# Altium schematic sheet [06] - Backlight LEDs.SchDoc("[06] - Backlight LEDs")
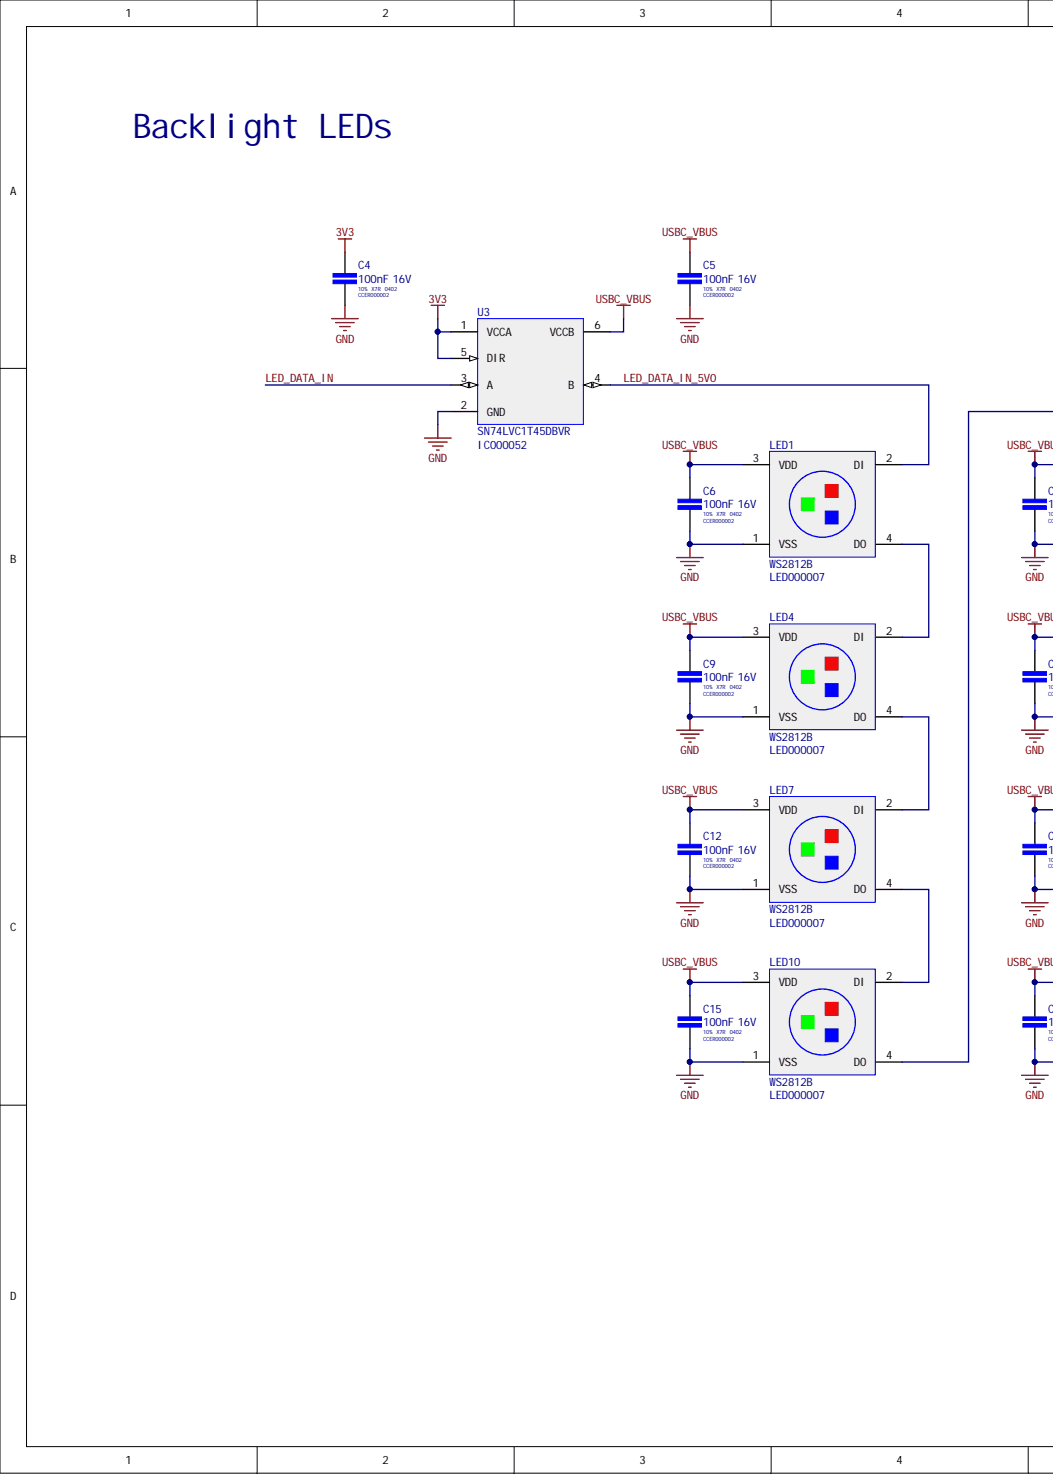
[diagram p0: [06] - Backlight LEDs.SchDoc, left]
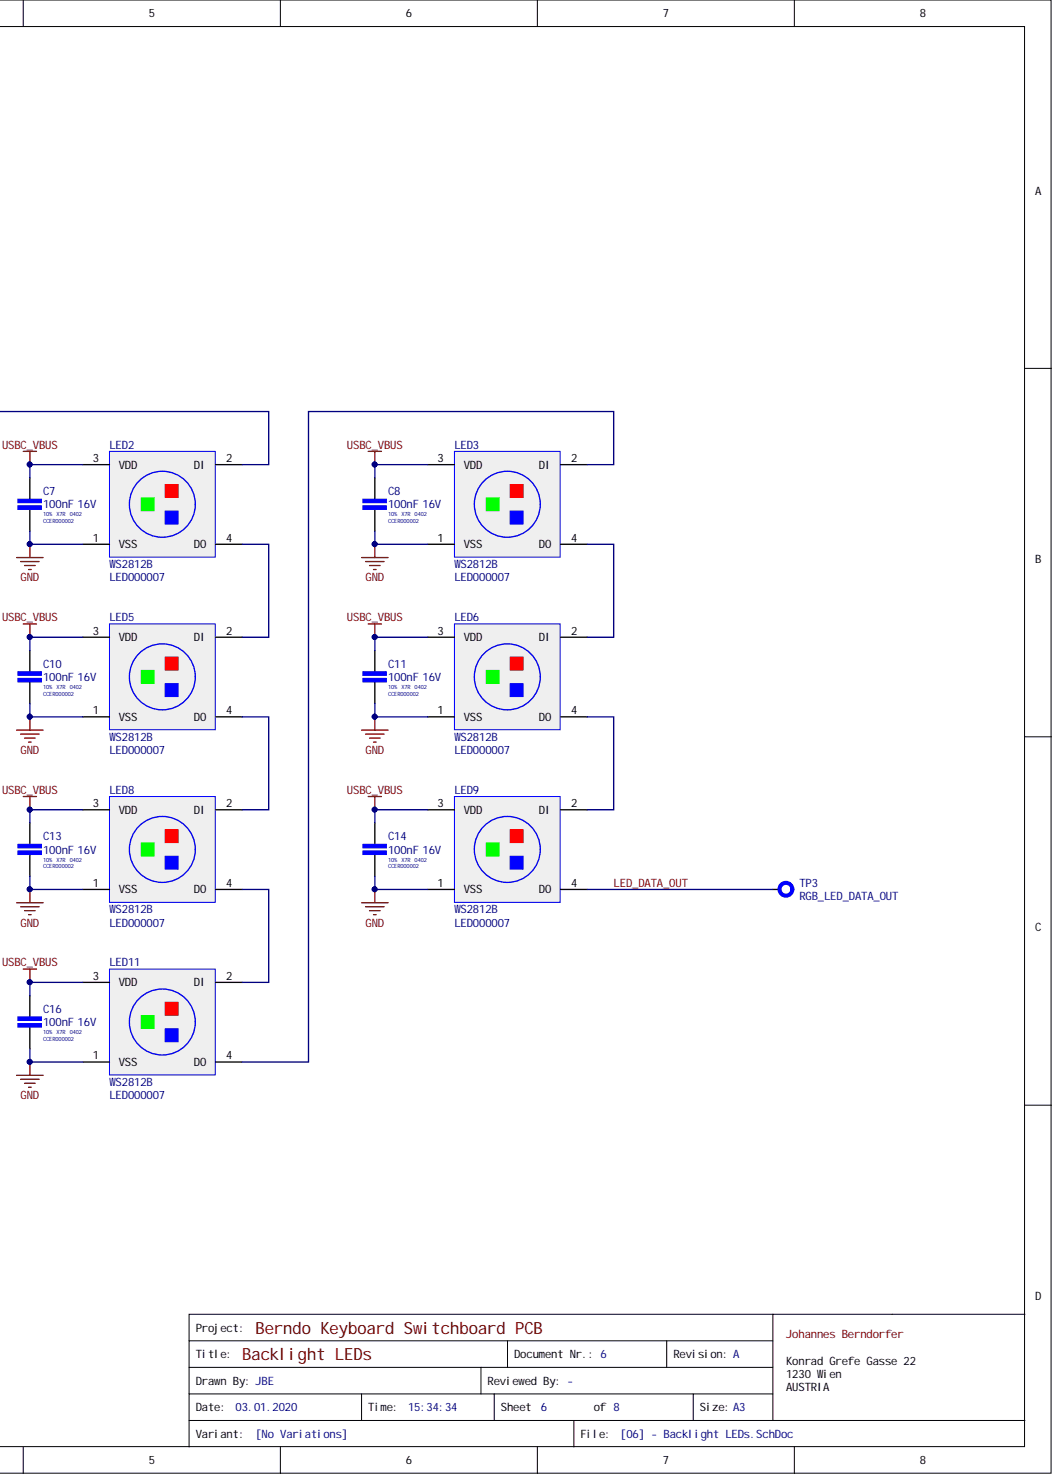
[diagram p1: [06] - Backlight LEDs.SchDoc, right]

## Parts
C4  100nF 16V  Tolerance: 10%  Internal Part Number: CCER000002  Dielectric: X7R  Case Code (Imperial): 0402  Capacitance: 100nF  [CAP-SMD-0402-0.55MM-IPC-MED]
C5  100nF 16V  Tolerance: 10%  Internal Part Number: CCER000002  Dielectric: X7R  Case Code (Imperial): 0402  Capacitance: 100nF  [CAP-SMD-0402-0.55MM-IPC-MED]
C6  100nF 16V  Tolerance: 10%  Internal Part Number: CCER000002  Dielectric: X7R  Capacitance: 100nF  [CAP-SMD-0402-0.55MM-IPC-MED]
C7  100nF 16V  Tolerance: 10%  Internal Part Number: CCER000002  Dielectric: X7R  Capacitance: 100nF  [CAP-SMD-0402-0.55MM-IPC-MED]
C8  100nF 16V  Tolerance: 10%  Internal Part Number: CCER000002  Dielectric: X7R  Capacitance: 100nF  [CAP-SMD-0402-0.55MM-IPC-MED]
C9  100nF 16V  Tolerance: 10%  Internal Part Number: CCER000002  Dielectric: X7R  Capacitance: 100nF  [CAP-SMD-0402-0.55MM-IPC-MED]
C10  100nF 16V  Tolerance: 10%  Internal Part Number: CCER000002  Dielectric: X7R  Capacitance: 100nF  [CAP-SMD-0402-0.55MM-IPC-MED]
C11  100nF 16V  Tolerance: 10%  Internal Part Number: CCER000002  Dielectric: X7R  Capacitance: 100nF  [CAP-SMD-0402-0.55MM-IPC-MED]
C12  100nF 16V  Tolerance: 10%  Internal Part Number: CCER000002  Dielectric: X7R  Capacitance: 100nF  [CAP-SMD-0402-0.55MM-IPC-MED]
C13  100nF 16V  Tolerance: 10%  Internal Part Number: CCER000002  Dielectric: X7R  Capacitance: 100nF  [CAP-SMD-0402-0.55MM-IPC-MED]
C14  100nF 16V  Tolerance: 10%  Internal Part Number: CCER000002  Dielectric: X7R  Capacitance: 100nF  [CAP-SMD-0402-0.55MM-IPC-MED]
C15  100nF 16V  Tolerance: 10%  Internal Part Number: CCER000002  Dielectric: X7R  Capacitance: 100nF  [CAP-SMD-0402-0.55MM-IPC-MED]
C16  100nF 16V  Tolerance: 10%  Internal Part Number: CCER000002  Dielectric: X7R  Capacitance: 100nF  [CAP-SMD-0402-0.55MM-IPC-MED]
LED1  WS2812B  Internal Part Number: LED000007  [LED-SMD-4-SMD-5050-WS2812B]
LED2  WS2812B  Internal Part Number: LED000007  [LED-SMD-4-SMD-5050-WS2812B]
LED3  WS2812B  Internal Part Number: LED000007  [LED-SMD-4-SMD-5050-WS2812B]
LED4  WS2812B  Internal Part Number: LED000007  [LED-SMD-4-SMD-5050-WS2812B]
LED5  WS2812B  Internal Part Number: LED000007  [LED-SMD-4-SMD-5050-WS2812B]
LED6  WS2812B  Internal Part Number: LED000007  [LED-SMD-4-SMD-5050-WS2812B]
LED7  WS2812B  Internal Part Number: LED000007  [LED-SMD-4-SMD-5050-WS2812B]
LED8  WS2812B  Internal Part Number: LED000007  [LED-SMD-4-SMD-5050-WS2812B]
LED9  WS2812B  Internal Part Number: LED000007  [LED-SMD-4-SMD-5050-WS2812B]
LED10  WS2812B  Internal Part Number: LED000007  [LED-SMD-4-SMD-5050-WS2812B]
LED11  WS2812B  Internal Part Number: LED000007  [LED-SMD-4-SMD-5050-WS2812B]
TP3  RGB_LED_DATA_OUT  [TP-SMD-CIRCULAR-1MM]
U3  SN74LVC1T45DBVR  Internal Part Number: IC000052  [SOT-23-6-H1.45MM-TI]

## Nets
3V3: C4.1 U3.1(VCCA) U3.5(DIR)
GND: C4.2 C5.2 C6.2 C7.2 C8.2 C9.2 C10.2 C11.2 C12.2 C13.2 C14.2 C15.2 C16.2 LED1.1(VSS) LED2.1(VSS) LED3.1(VSS) LED4.1(VSS) LED5.1(VSS) LED6.1(VSS) LED7.1(VSS) LED8.1(VSS) LED9.1(VSS) LED10.1(VSS) LED11.1(VSS) U3.2(GND)
USBC_VBUS: C5.1 C6.1 C7.1 C8.1 C9.1 C10.1 C11.1 C12.1 C13.1 C14.1 C15.1 C16.1 LED1.3(VDD) LED2.3(VDD) LED3.3(VDD) LED4.3(VDD) LED5.3(VDD) LED6.3(VDD) LED7.3(VDD) LED8.3(VDD) LED9.3(VDD) LED10.3(VDD) LED11.3(VDD) U3.6(VCCB)
LED_DATA_IN: U3.3(A)
LED_DATA_IN_5V0: LED1.2(DI) U3.4(B)
LED_DATA_OUT: LED9.4(DO) TP3.1
NetLED1_4: LED1.4(DO) LED4.2(DI)
NetLED2_2: LED2.2(DI) LED10.4(DO)
NetLED2_4: LED2.4(DO) LED5.2(DI)
NetLED3_2: LED3.2(DI) LED11.4(DO)
NetLED3_4: LED3.4(DO) LED6.2(DI)
NetLED4_4: LED4.4(DO) LED7.2(DI)
NetLED5_4: LED5.4(DO) LED8.2(DI)
NetLED6_4: LED6.4(DO) LED9.2(DI)
NetLED7_4: LED7.4(DO) LED10.2(DI)
NetLED8_4: LED8.4(DO) LED11.2(DI)

## Library data of the parts
C4-C16 = CL05B104KO5NNNC: CAP CER 100N 16V X7R 10% SMD 0402 125C SAMSUNG; Material: Ceramic; Width: 0.02inch; Voltage Rating (DC): 16V; Voltage Rating: 16V; Voltage: 16V; Thickness: 0.022inch; Supplier Part Number 1: 1276-1001-6-ND; Supplier 1: Digi-Key; Stock 1: 53930414; RoHS: Yes; Radiation Hardening: No; Pricing 1: 1=0,10000, 10=0,02400, 100=0,01070, 500=0,00766, 1000=0,00602, 2500=0,00548, 5000=0,00504 (USD); Packaging: Tape and Reel; Package Quantity: 1; Mount: Surface Mount; Min Operating Temperature: -55°C; Max Operating Temperature: 125°C; Manufacturer Part Number 1: CL05B104KO5NNNC; Manufacturer 1: Samsung; Library Name: Altium_Lib_Standard.IntLib; Length: 1mm; Lead Free: Lead Free; Height: 0.5mm; Depth: 0.5mm; Contact Plating: Tin; ComponentLink4URL: https://datasheet.ciiva.com/26309/mlcc-catalogdownload2013-eng-26309003.pdf?src-supplier=Distrelec; ComponentLink4Description: https://datasheet.ciiva.com/26309/mlcc-catalogdownload2013-eng-26309003.pdf?src-supplier=Distrelec; ComponentLink3URL: https://datasheet.ciiva.com/16208/cl05b104kq5nnnc-16208343.pdf?src-supplier=Future+Electronics; ComponentLink3Description: https://datasheet.ciiva.com/16208/cl05b104kq5nnnc-16208343.pdf?src-supplier=Future+Electronics; ComponentLink2URL: https://datasheet.ciiva.com/29198/458718-da-01-en-vs-kondensator-0402-29198423.pdf?src-supplier=Conrad; ComponentLink2Description: https://datasheet.ciiva.com/29198/458718-da-01-en-vs-kondensator-0402-29198423.pdf?src-supplier=Conrad; ComponentLink1URL: https://datasheet.ciiva.com/26920/getdatasheetpartid-359683-26920169.pdf?src-supplier=Verical; ComponentLink1Description: https://datasheet.ciiva.com/26920/getdatasheetpartid-359683-26920169.pdf?src-supplier=Verical; Component Kind: Standard; Case Code (Metric): 1005; Case/Package: 0402
  C6-C16: Case Code (Imperial): 0402
LED1-LED11 = WS2812B: LED RGB-ADDRESSABLE SERIAL 5050; Library Name: Altium_Lib_Standard.IntLib; Component Kind: Standard
TP3 = TESTPOINT_CIRCULAR_1MM: Library Name: Altium_Lib_Standard.IntLib; Component Kind: Standard
U3 = SN74LVC1T45DBVR: IC LEVEL SHIFTER BIDIR TRISTATE 1CH SOT-23-6 1V65-5V5; Manufacturer: Texas Instruments; Turn-On Delay Time: 36.2ns; Quiescent Current: 3uA; Propagation Delay: 3.9ns; Polarity: Non-Inverting; Number of Inputs: 1; Min Supply Voltage: 1.65V; Max Supply Voltage: 5.5V; Low Level Output Current: 32mA; Logic Function: Translator,Transceiver; High Level Output Current: -32mA; Direction: Bidirectional; Data Rate: 420Mbps; Width: 1.3mm; Weight: 0.000229oz; Supplier Part Number 1: 296-16843-1-ND; Supplier 1: Digi-Key; Stock 1: 70407; RoHS: Yes; REACH SVHC: No SVHC; Radiation Hardening: No; Pricing 1: 1 = 0,60000, 10 = 0,51000, 100 = 0,38070, 500 = 0,29912, 1000 = 0,23113 (USD); Packaging: Tape and Reel; Package Quantity: 3000; Number of Pins: 6; Number of Elements: 1; Number of Channels: 1; Number of Bits: 1; Mount: Surface Mount; Min Operating Temperature: -40°C; Max Operating Temperature: 85°C; Manufacturer Part Number: SN74LVC1T45DBVR; Library Name: Altium_Lib_Standard.IntLib; Length: 3.05mm; Lead Free: Lead Free; Height: 1.75mm; Contact Plating: Gold; ComponentLink8URL: https://datasheet.ciiva.com/1899/sn74lvc1t45-1899262.pdf?src-supplier=Digi-Key; ComponentLink8Description: https://datasheet.ciiva.com/1899/sn74lvc1t45-1899262.pdf?src-supplier=Digi-Key; ComponentLink7URL: https://datasheet.ciiva.com/25973/sn74lvc1t45-25973997.pdf?src-supplier=Avnet+Europe; ComponentLink7Description: https://datasheet.ciiva.com/25973/sn74lvc1t45-25973997.pdf?src-supplier=Avnet+Europe; ComponentLink6URL: https://datasheet.ciiva.com/1899/sn74lvc1t45-1899261.pdf?src-supplier=Digi-Key; ComponentLink6Description: https://datasheet.ciiva.com/1899/sn74lvc1t45-1899261.pdf?src-supplier=Digi-Key; ComponentLink5URL: https://datasheet.ciiva.com/1899/sn74lvc1t45-1899260.pdf?src-supplier=Digi-Key; ComponentLink5Description: https://datasheet.ciiva.com/1899/sn74lvc1t45-1899260.pdf?src-supplier=Digi-Key; ComponentLink4URL: https://datasheet.ciiva.com/7293/1862463-7293669.pdf?src-supplier=Newark; ComponentLink4Description: https://datasheet.ciiva.com/7293/1862463-7293669.pdf?src-supplier=Newark; ComponentLink3URL: https://datasheet.ciiva.com/15086/getdatasheetpartid-309359-15086627.pdf?src-supplier=Verical; ComponentLink3Description: https://datasheet.ciiva.com/15086/getdatasheetpartid-309359-15086627.pdf?src-supplier=Verical; ComponentLink2URL: https://datasheet.ciiva.com/23943/1772421-23943378.pdf?src-supplier=Newark; ComponentLink2Description: https://datasheet.ciiva.com/23943/1772421-23943378.pdf?src-supplier=Newark; ComponentLink1URL: https://datasheet.ciiva.com/27055/2000549-27055985.pdf?src-supplier=Jameco; ComponentLink1Description: https://datasheet.ciiva.com/27055/2000549-27055985.pdf?src-supplier=Jameco; Component Kind: Standard; Case/Package: SOT-23
